# Altium schematic sheet Steering Wheel Interface.SchDoc("Steering Wheel Interface")
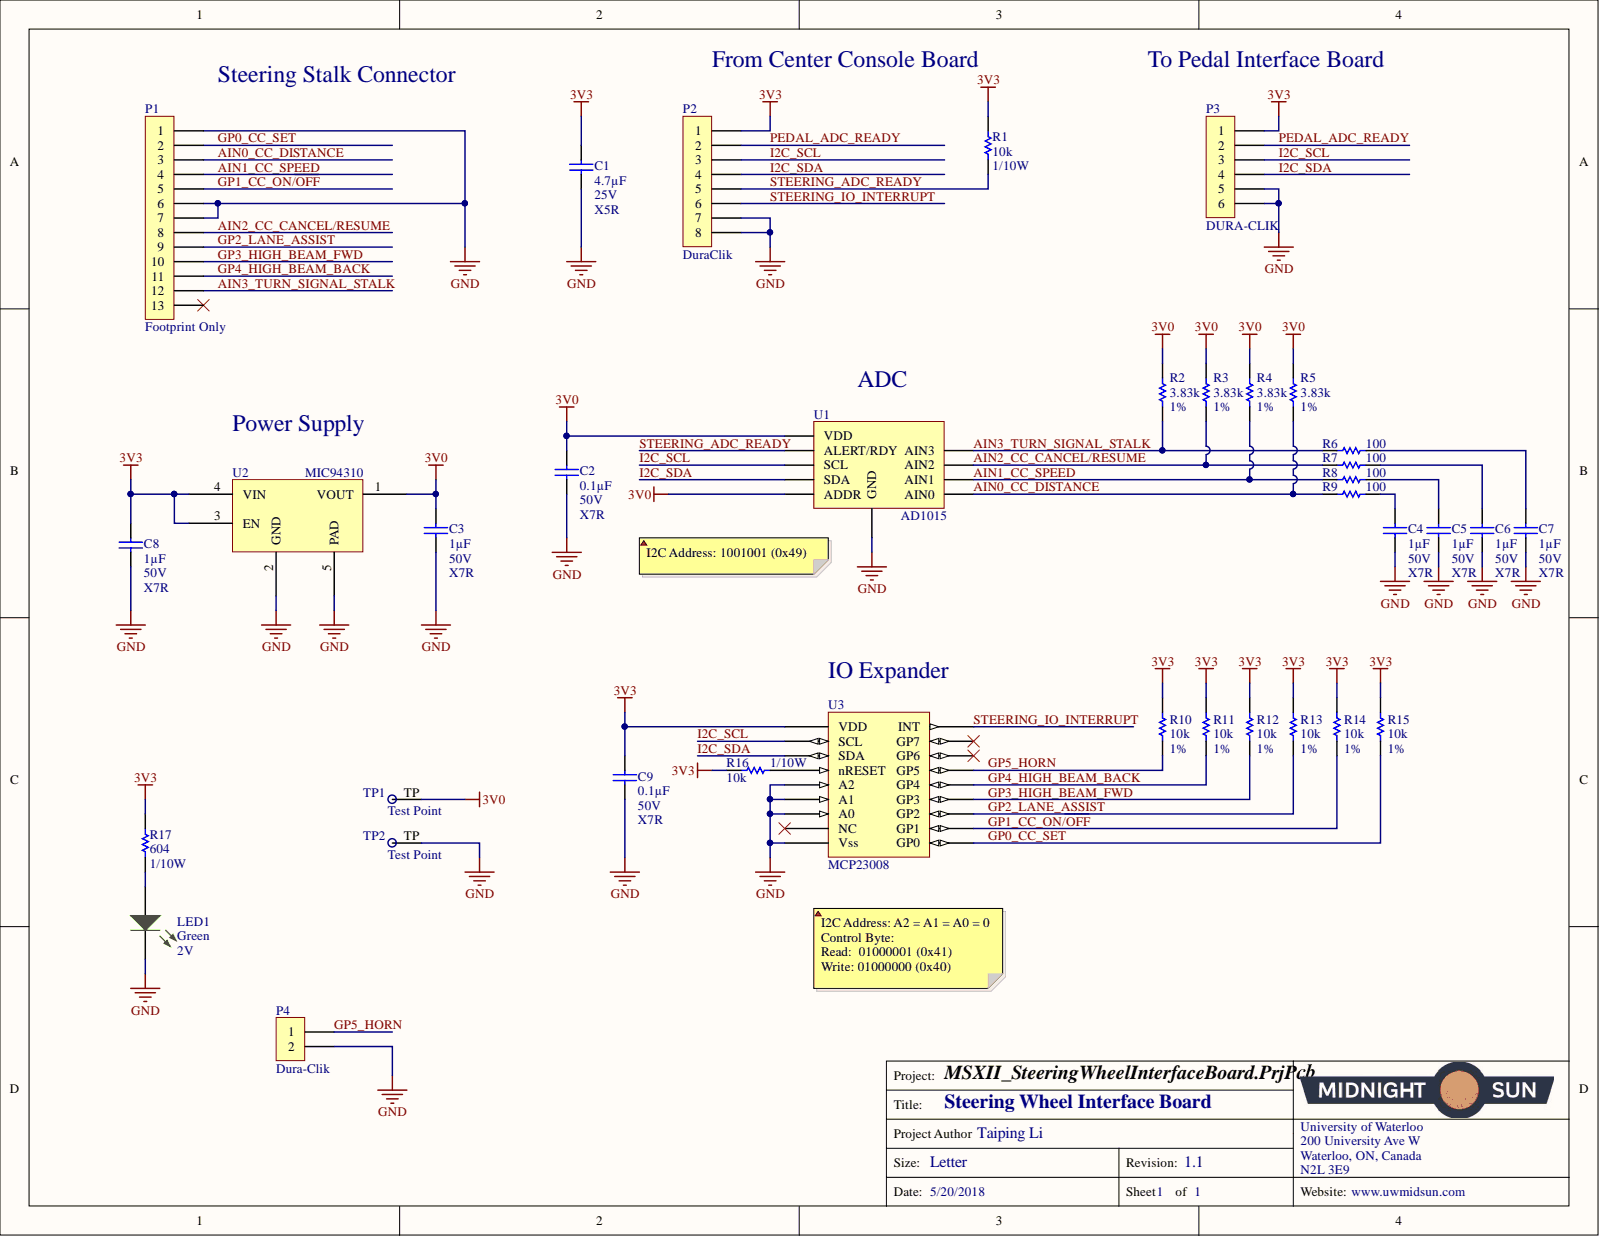
[diagram p0: Steering Wheel Interface.SchDoc]

## Parts
C1  Voltage: 25V  Temperature Coefficient: X5R  Capacitance: 4.7µF  [CAP, 0603]  (hidden comment: CAP CER 4.7UF 25V 10% X5R 0603)
C2  Voltage: 50V  Temperature Coefficient: X7R  Capacitance: 0.1µF  [CAP, 0603]  (hidden comment: CAP CER 0.1UF 50V 10% X7R 0603)
C3  Voltage: 50V  Temperature Coefficient: X7R  Capacitance: 1µF  [CAP, 0603]  (hidden comment: CAP CER 1UF 50V 10% X7R 0603)
C4  Voltage: 50V  Temperature Coefficient: X7R  Capacitance: 1µF  [CAP, 0603]  (hidden comment: CAP CER 1UF 50V 10% X7R 0603)
C5  Voltage: 50V  Temperature Coefficient: X7R  Capacitance: 1µF  [CAP, 0603]  (hidden comment: CAP CER 1UF 50V 10% X7R 0603)
C6  Voltage: 50V  Temperature Coefficient: X7R  Capacitance: 1µF  [CAP, 0603]  (hidden comment: CAP CER 1UF 50V 10% X7R 0603)
C7  Voltage: 50V  Temperature Coefficient: X7R  Capacitance: 1µF  [CAP, 0603]  (hidden comment: CAP CER 1UF 50V 10% X7R 0603)
C8  Voltage: 50V  Temperature Coefficient: X7R  Capacitance: 1µF  [CAP, 0603]  (hidden comment: CAP CER 1UF 50V 10% X7R 0603)
C9  Voltage: 50V  Temperature Coefficient: X7R  Capacitance: 0.1µF  [CAP, 0603]  (hidden comment: CAP CER 0.1UF 50V 10% X7R 0603)
LED1  Voltage - Forward (Vf) (Typ): 2V  Color: Green  [LED_0603]  (hidden comment: LED GREEN CLEAR 2V 0603)
P1  Footprint Only  [CONN 13POS CARD EDGE]  (no BOM)
P2  Series: DuraClik  [CONN, 8POS Dura-Clik]  (hidden comment: CONN 8POS DURA-CLIK 0.079")
P3  DURA-CLIK  [CONN, 6POS DURA-CLIK VERT]
P4  Dura-Clik  [CONN, 2POS Dura-Click Vertical]
R1  Resistance: 10k  Power: 1/10W  [RES, 0603]  (hidden comment: RES 10K OHM 1% 1/10W 0603)
R2  Tolerance: 1%  Resistance: 3.83k  [RES, 0603]  (hidden comment: RES 3.83K OHM 1% 1/10W 0603)
R3  Tolerance: 1%  Resistance: 3.83k  [RES, 0603]  (hidden comment: RES 3.83K OHM 1% 1/10W 0603)
R4  Tolerance: 1%  Resistance: 3.83k  [RES, 0603]  (hidden comment: RES 3.83K OHM 1% 1/10W 0603)
R5  Tolerance: 1%  Resistance: 3.83k  [RES, 0603]  (hidden comment: RES 3.83K OHM 1% 1/10W 0603)
R6  RES 100 OHM 1% 1/10W 0603  [RES, 0603]  (value not drawn)
R7  RES 100 OHM 1% 1/10W 0603  [RES, 0603]  (value not drawn)
R8  RES 100 OHM 1% 1/10W 0603  [RES, 0603]  (value not drawn)
R9  RES 100 OHM 1% 1/10W 0603  [RES, 0603]  (value not drawn)
R10  Tolerance: 1%  Resistance: 10k  [RES, 0603]  (hidden comment: RES 10K OHM 1% 1/10W 0603)
R11  Tolerance: 1%  Resistance: 10k  [RES, 0603]  (hidden comment: RES 10K OHM 1% 1/10W 0603)
R12  Tolerance: 1%  Resistance: 10k  [RES, 0603]  (hidden comment: RES 10K OHM 1% 1/10W 0603)
R13  Tolerance: 1%  Resistance: 10k  [RES, 0603]  (hidden comment: RES 10K OHM 1% 1/10W 0603)
R14  Tolerance: 1%  Resistance: 10k  [RES, 0603]  (hidden comment: RES 10K OHM 1% 1/10W 0603)
R15  Tolerance: 1%  Resistance: 10k  [RES, 0603]  (hidden comment: RES 10K OHM 1% 1/10W 0603)
R16  Resistance: 10k  Power: 1/10W  [RES, 0603]  (hidden comment: RES 10K OHM 1% 1/10W 0603)
R17  Power: 1/10W  [RES, 0603]  (hidden comment: RES 604 OHM 1% 1/10W 0603)
TP1  Test Point  [Test Point]  (no BOM)
TP2  Test Point  [Test Point]  (no BOM)
U1  AD1015  [IC ADC VSSOP10]
U2  MIC94310  [IC REG LDO 3V 0.2A 4-TDFN]
U3  MCP23008  [IC 18SOIC]

## Nets
3V0: C2.1 C3.1 R2.1 R3.1 R4.1 R5.1 TP1.TP U1.1(ADDR) U1.8(VDD) U2.1(VOUT)
3V3: C1.1 C8.1 C9.1 P2.1 P3.1 R1.1 R10.1 R11.1 R12.1 R13.1 R14.1 R15.1 R16.1 R17.1 U2.3(EN) U2.4(VIN) U3.18(VDD)
GND: C1.2 C2.2 C3.2 C4.2 C5.2 C6.2 C7.2 C8.2 C9.2 LED1.2 P1.1 P1.6 P1.7 P2.7 P2.8 P3.5 P3.6 P4.2 TP2.TP U1.3(GND) U2.2(GND) U2.5(PAD) U3.3(A2) U3.4(A1) U3.5(A0) U3.9(Vss)
AIN0_CC_DISTANCE: P1.3 R5.2 R9.1 U1.4(AIN0)
AIN1_CC_SPEED: P1.4 R4.2 R8.1 U1.5(AIN1)
AIN2_CC_CANCEL/RESUME: P1.8 R3.2 R7.1 U1.6(AIN2)
AIN3_TURN_SIGNAL_STALK: P1.12 R2.2 R6.1 U1.7(AIN3)
GP0_CC_SET: P1.2 R15.2 U3.10(GP0)
GP1_CC_ON/~{OFF}: P1.5 R14.2 U3.11(GP1)
GP2_LANE_ASSIST: P1.9 R13.2 U3.12(GP2)
GP3_HIGH_BEAM_FWD: P1.10 R12.2 U3.13(GP3)
GP4_HIGH_BEAM_BACK: P1.11 R11.2 U3.14(GP4)
GP5_HORN: P4.1 R10.2 U3.15(GP5)
I2C_SCL: P2.3 P3.3 U1.10(SCL) U3.1(SCL)
I2C_SDA: P2.4 P3.4 U1.9(SDA) U3.2(SDA)
PEDAL_ADC_READY: P2.2 P3.2
STEERING_ADC_READY: P2.5 R1.2 U1.2(ALERT/RDY)
STEERING_IO_INTERRUPT: P2.6 U3.8(INT)
NetC4_1: C4.1 R9.2
NetC5_1: C5.1 R8.2
NetC6_1: C6.1 R7.2
NetC7_1: C7.1 R6.2
NetLED1_1: LED1.1 R17.2
NetR16_2: R16.2 U3.6(nRESET)

Not connected: P1 13; U3 7(NC) 16(GP6) 17(GP7)

## Library data of the parts
C1 = CAP CER 4.7UF 25V 10% X5R 0603: 4.7µF ±10% 25V Ceramic Capacitor X5R 0603 (1608 Metric); Manufacturer Part Number 1: GRM188R61E475KE11D; Manufacturer 1: Murata Electronics North America; Tolerance: ±10%; Thickness (Max): 0.031" (0.80mm); Supplier Part Number 1: 490-7203-1-ND; Supplier 1: Digi-Key; Size / Dimension: 0.063" L x 0.031" W (1.60mm x 0.80mm); Ratings: -; Part Status: Active; Packaging: Cut Tape (CT); Package / Case: 0603 (1608 Metric); Operating Temperature: -55°C ~ 85°C; Mounting Type: Surface Mount, MLCC; Lead Style: -; Lead Spacing: -; Height - Seated (Max): -; ComponentLink1URL: http://www.murata.com/~/media/webrenewal/support/library/catalog/products/capacitor/mlcc/c02e.ashx; ComponentLink1Description: http://www.murata.com/~/media/webrenewal/support/library/catalog/products/capacitor/mlcc/c02e.ashx; Component Kind: Standard; Library Name: Schematic Diagrams.SchLib
C2 C9 = CAP CER 0.1UF 50V 10% X7R 0603: 0.10µF ±10% 50V Ceramic Capacitor X7R 0603 (1608 Metric); Manufacturer Part Number 1: 06035C104KAT2A; Manufacturer 1: AVX Corporation; Tolerance: ±10%; Thickness (Max): 0.035" (0.90mm); Supplier Part Number 1: 478-5052-1-ND; Supplier 1: Digi-Key; Size / Dimension: 0.063" L x 0.032" W (1.60mm x 0.81mm); Ratings: -; Part Status: Active; Packaging: Cut Tape (CT); Package / Case: 0603 (1608 Metric); Operating Temperature: -55°C ~ 125°C; Mounting Type: Surface Mount, MLCC; Lead Style: -; Lead Spacing: -; Height - Seated (Max): -; ComponentLink1URL: http://datasheets.avx.com/X7RDielectric.pdf; ComponentLink1Description: http://datasheets.avx.com/X7RDielectric.pdf; Component Kind: Standard; Library Name: Schematic Diagrams.SchLib
C3-C8 = CAP CER 1UF 50V 10% X7R 0603: 1µF ±10% 50V Ceramic Capacitor X7R 0603 (1608 Metric); Manufacturer Part Number 1: UMK107AB7105KA-T; Manufacturer 1: Taiyo Yuden; Tolerance: ±10%; Thickness (Max): 0.037" (0.95mm); Supplier Part Number 1: 587-3247-1-ND; Supplier 1: Digi-Key; Size / Dimension: 0.063" L x 0.031" W (1.60mm x 0.80mm); Ratings: -; Part Status: Active; Packaging: Cut Tape (CT); Package / Case: 0603 (1608 Metric); Operating Temperature: -55°C ~ 125°C; Mounting Type: Surface Mount, MLCC; Lead Style: -; Lead Spacing: -; Height - Seated (Max): -; ComponentLink1URL: http://www.yuden.co.jp/productdata/catalog/en/mlcc_all_e.pdf; ComponentLink1Description: http://www.yuden.co.jp/productdata/catalog/en/mlcc_all_e.pdf; Component Kind: Standard; Library Name: Schematic Diagrams.SchLib
LED1 = LED GREEN CLEAR 2V 0603: Green 572nm LED Indication - Discrete 2V 0603 (1608 Metric); Manufacturer Part Number: 150060VS75000; Manufacturer: Wurth Electronics Inc.; Wavelength - Dominant: 570nm; Viewing Angle: 140°; Millicandela Rating: 40mcd; Lens Style/Size: Rectangle with Flat Top, 1.10mm x 0.70mm; Lens Color: Colorless; Current - Test: 20mA; Wavelength - Peak: 572nm; Supplier Part Number 1: 732-4980-1-ND; Supplier Device Package: 0603; Supplier 1: Digi-Key; Stock 1: 9408; Size / Dimension: 1.60mm L x 0.80mm W; RoHS: RoHS Compliant; Pricing 1: 1=0.21, 100=0.1617, 500=0.13964 (CAD); Part Status: Active; Packaging: Cut Tape (CT); Package / Case: 0603 (1608 Metric); Mounting Type: Surface Mount; Lens Transparency: Clear; Height (Max): 0.80mm; Features: -; ComponentLink1URL: http://katalog.we-online.de/led/datasheet/150060VS75000.pdf; ComponentLink1Description: http://katalog.we-online.de/led/datasheet/150060VS75000.pdf; Component Kind: Standard; Category: Optoelectronics; Library Name: Schematic Diagrams.SchLib
P1 = CONN 13POS 0.1" CARD EDGE: Library Name: Schematic Diagrams.SchLib
P2 = CONN 8POS DURA-CLIK 0.079": 8 Positions Header Connector 0.079" (2.00mm) Surface Mount Tin; Manufacturer Part Number 1: 5600200820; Manufacturer 1: Molex, LLC; Style: Board to Cable/Wire; Shrouding: Shrouded - 4 Wall; Number of Positions Loaded: All; Number of Positions: 8; Mated Stacking Heights: 9.3mm; Voltage: -; Termination: Solder; Supplier Part Number 1: WM10868CT-ND; Supplier 1: Digi-Key; Row Spacing - Mating: -; Pitch - Mating: 0.079" (2.00mm); Part Status: Active; Packaging: Cut Tape (CT); Overall Contact Length: -; Operating Temperature: -; Number of Rows: 1; Mounting Type: Surface Mount; Material Flammability Rating: -; Insulation Material: Polyamide (PA), Nylon; Insulation Height: 0.315" (8.00mm); Insulation Color: Natural; Ingress Protection: -; Fastening Type: Latch Lock; Current Rating: -; Contact Type: Male Pin; Contact Shape: Square; Contact Material: Copper Alloy; Contact Length - Post: -; Contact Length - Mating: -; Contact Finish Thickness - Post: -; Contact Finish Thickness - Mating: -; Contact Finish - Post: Tin; Contact Finish - Mating: Tin; Connector Type: Header; ComponentLink1URL: http://www.molex.com/pdm_docs/sd/5600200820_sd.pdf; ComponentLink1Description: http://www.molex.com/pdm_docs/sd/5600200820_sd.pdf; Component Kind: Standard; Library Name: Schematic Diagrams.SchLib
P3 = CONN 6POS DURA-CLIK 0.079": 6 Positions Header, Shrouded Connector 0.079" (2.00mm) Surface Mount, Vertical Tin; Manufacturer Part Number: 5600200820; Manufacturer: Molex; Style: Board to Cable/Wire; Shrouding: Shrouded - 4 Wall; Number of Positions Loaded: All; Number of Positions: 6; Mated Stacking Heights: 9.3mm; Voltage Rating: -; Termination: Solder; Supplier Part Number 1: WM10866CT-ND; Supplier 1: Digi-Key; Stock 1: 3826; Row Spacing - Mating: -; RoHS: RoHS Compliant; Pricing 1: 1=1.76, 10=1.542, 25=1.4512, 50=1.391, 100=1.3305, 250=1.20956 (CAD); Pitch - Mating: 0.079" (2.00mm); Part Status: Active; Packaging: Cut Tape (CT); Overall Contact Length: -; Operating Temperature: -; Number of Rows: 1; Mounting Type: Surface Mount; Material Flammability Rating: -; Insulation Material: Polyamide (PA), Nylon; Insulation Height: 0.315" (8.00mm); Insulation Color: Natural; Ingress Protection: -; Features: Solder Retention; Fastening Type: Latch Lock; Current Rating: -; Contact Type: Male Pin; Contact Shape: Square; Contact Material: Copper Alloy; Contact Length - Post: -; Contact Length - Mating: -; Contact Finish Thickness - Post: -; Contact Finish Thickness - Mating: -; Contact Finish - Post: Tin; Contact Finish - Mating: Tin; Connector Type: Header; ComponentLink1URL: http://www.molex.com/pdm_docs/sd/5600200220_sd.pdf; ComponentLink1Description: http://www.molex.com/pdm_docs/sd/5600200220_sd.pdf; Component Kind: Standard; Category: Connectors, Interconnects; Applications: Automotive, Industrial; Library Name: Schematic Diagrams.SchLib
P4 = CONN 2POS DURA-CLIK 0.079" VERT: 2 Positions Header, Shrouded Connector 0.079" (2.00mm) Surface Mount, Vertical Tin; Manufacturer Part Number: 5600200220; Manufacturer: Molex, LLC; Style: Board to Cable/Wire; Shrouding: Shrouded - 4 Wall; Number of Positions Loaded: All; Number of Positions: 2; Mated Stacking Heights: 9.3mm; Voltage Rating: -; Termination: Solder; Supplier Part Number 1: WM10862CT-ND; Supplier 1: Digi-Key; Stock 1: 11912; Row Spacing - Mating: -; RoHS: RoHS Compliant; Pricing 1: 1=1.16, 10=1.012, 25=0.952, 50=0.9122, 100=0.8727, 250=0.79336 (CAD); Pitch - Mating: 0.079" (2.00mm); Part Status: Active; Packaging: Cut Tape (CT); Overall Contact Length: -; Operating Temperature: -; Number of Rows: 1; Mounting Type: Surface Mount; Material Flammability Rating: -; Insulation Material: Polyamide (PA), Nylon; Insulation Height: 0.315" (8.00mm); Insulation Color: Natural; Ingress Protection: -; Features: Solder Retention; Fastening Type: Latch Lock; Current Rating: -; Contact Type: Male Pin; Contact Shape: Square; Contact Material: Copper Alloy; Contact Length - Post: -; Contact Length - Mating: -; Contact Finish Thickness - Post: -; Contact Finish Thickness - Mating: -; Contact Finish - Post: Tin; Contact Finish - Mating: Tin; Connector Type: Header; ComponentLink1URL: http://www.molex.com/pdm_docs/sd/5600200220_sd.pdf; ComponentLink1Description: http://www.molex.com/pdm_docs/sd/5600200220_sd.pdf; Component Kind: Standard; Category: Connectors, Interconnects; Applications: Automotive, Industrial; Library Name: Schematic Diagrams.SchLib
R1 R10-R16 = RES 10K OHM 1% 1/10W 0603: RES SMD 10K OHM 1% 1/10W 0603; Manufacturer Part Number 1: RC0603FR-0710KL; Manufacturer 1: Yageo; Temperature Coefficient: ±100ppm/°C; Supplier Part Number 1: 311-10.0KHRCT-ND; Supplier Device Package: 0603; Supplier 1: Digi-Key; Size / Dimension: 0.063" L x 0.031" W (1.60mm x 0.80mm); Part Status: Active; Packaging: Cut Tape (CT); Package / Case: 0603 (1608 Metric); Operating Temperature: -55°C ~ 155°C; Height - Seated (Max): 0.022" (0.55mm); Failure Rate: -; Composition: Thick Film; ComponentLink1URL: http://www.yageo.com/documents/recent/PYu-RC_Group_51_RoHS_L_7.pdf; ComponentLink1Description: http://www.yageo.com/documents/recent/PYu-RC_Group_51_RoHS_L_7.pdf; Component Kind: Standard; Library Name: Schematic Diagrams.SchLib
  R1 R16: Tolerance: ±1%
  R10-R15: Power: 1/10W
R2-R5 = RES 3.83K OHM 1% 1/10W 0603: 3.83 kOhms ±1% 0.1W, 1/10W Chip Resistor 0603 (1608 Metric) Moisture Resistant Thick Film; Manufacturer Part Number: RC0603FR-073K83L; Manufacturer: Yageo; Working Voltage: 75V; Voltage Rating (DC): 50V; Temperature Coefficient: 100ppm/°C; Width: 0.8mm; Weight: 7.1E-05oz; Voltage Rating: 75V; Termination: SMD/SMT; Supplier Part Number 1: 311-3.83KHRCT-ND; Supplier 1: Digi-Key; Stock 1: 150132; Pricing 1: 1=0.1, 10=0.015, 100=0.006, 1000=0.00268, 2500=0.00233 (USD); Power Rating: 1/10 W; Packaging: Tape and Reel; Number of Terminations: 2; Mount: Surface Mount; Min Operating Temperature: -55°C; Military Standard: Not; Max Operating Temperature: 155°C; Length: 1.6mm; Lead Free: Lead Free; Height: 0.45mm; Depth: 0.8mm; Composition: Thick Film; ComponentLink2URL: https://datasheet.ciiva.com/15189/getdatasheetpartid-327814-15189723.pdf; ComponentLink2Description: https://datasheet.ciiva.com/15189/getdatasheetpartid-327814-15189723.pdf; ComponentLink1URL: https://datasheet.ciiva.com/11819/0900766b80f96399-11819347.pdf; ComponentLink1Description: https://datasheet.ciiva.com/11819/0900766b80f96399-11819347.pdf; Component Kind: Standard; Case Code (Metric): 1608; Case Code (Imperial): 0603; Case/Package: 0603; Library Name: Schematic Diagrams.SchLib
R6-R9 = RES 100 OHM 1% 1/10W 0603: 100 Ohm ±1% 0.1W, 1/10W Chip Resistor 0603 (1608 Metric) Moisture Resistant Thick Film; Manufacturer Part Number 1: RC0603FR-07100RL; Manufacturer 1: Yageo; Temperature Coefficient: ±100ppm/°C; Resistance: 100; Tolerance: ±1%; Supplier Part Number 1: 311-100HRCT-ND; Supplier Device Package: 0603; Supplier 1: Digi-Key; Size / Dimension: 0.063" L x 0.031" W (1.60mm x 0.80mm); Part Status: Active; Packaging: Cut Tape (CT); Package / Case: 0603 (1608 Metric); Operating Temperature: -55°C ~ 155°C; Height - Seated (Max): 0.022" (0.55mm); Failure Rate: -; Composition: Thick Film; ComponentLink1URL: http://www.yageo.com/documents/recent/PYu-RC_Group_51_RoHS_L_7.pdf; ComponentLink1Description: http://www.yageo.com/documents/recent/PYu-RC_Group_51_RoHS_L_7.pdf; Component Kind: Standard; Library Name: Schematic Diagrams.SchLib
R17 = RES 604 OHM 1% 1/10W 0603: RES SMD 604 OHM 1% 1/10W 0603; Manufacturer Part Number 1: RC0603FR-07604RL; Manufacturer 1: Yageo; Temperature Coefficient: ±100ppm/°C; Resistance: 604; Tolerance: ±1%; Supplier Part Number 1: 311-604HRCT-ND; Supplier Device Package: 0603; Supplier 1: Digi-Key; Size / Dimension: 0.063" L x 0.031" W (1.60mm x 0.80mm); Part Status: Active; Packaging: Cut Tape (CT); Package / Case: 0603 (1608 Metric); Operating Temperature: -55°C ~ 155°C; Height - Seated (Max): 0.022" (0.55mm); Failure Rate: -; Composition: Thick Film; ComponentLink1URL: http://www.yageo.com/documents/recent/PYu-RC_Group_51_RoHS_L_7.pdf; ComponentLink1Description: http://www.yageo.com/documents/recent/PYu-RC_Group_51_RoHS_L_7.pdf; Component Kind: Standard; Library Name: Schematic Diagrams.SchLib
U1 = IC ADC 12-BIT VSSOP-10: 12 Bit Analog to Digital Converter 4 Input 1 Sigma-Delta 10-VSSOP; Manufacturer Part Number: ADS1015IDGSR; Manufacturer: Texas Instruments; Voltage - Supply, Digital: 2 V ~ 5.5 V; Sampling Rate (Per Second): 3.3k; Reference Type: Internal; Number of Inputs: 2, 4; Number of Bits: 12; Number of A/D Converters: 1; Input Type: Differential, Single Ended; Data Interface: I²C; Configuration: MUX-PGA-ADC; Voltage - Supply, Analog: 2 V ~ 5.5 V; Supplier Part Number 1: 296-41185-1-ND; Supplier Device Package: 10-VSSOP; Supplier 1: Digi-Key; Stock 1: 4084; RoHS: RoHS Compliant; Ratio - S/H: -; Pricing 1: 1=4.25, 10=3.807, 100=3.1194, 500=2.65552, 1000=2.23959 (CAD); Part Status: Active; Packaging: Cut Tape (CT); Package / Case: 10-TFSOP, 10-MSOP (0.118", 3.00mm Width); Operating Temperature: -40°C ~ 125°C; Features: PGA, Selectable Address; ComponentLink1URL: http://www.ti.com/lit/ds/symlink/ads1013.pdf; ComponentLink1Description: http://www.ti.com/lit/ds/symlink/ads1013.pdf; Component Kind: Standard; Category: Integrated Circuits (ICs); Architecture: Sigma-Delta; Library Name: Schematic Diagrams.SchLib
U2 = IC REG LDO 3V 0.2A 4-TDFN: Manufacturer Part Number: MIC94310-PYMT-TR; Manufacturer: Microchip Technology; Voltage - Output: 3V; Voltage - Input: Up to 3.6V; Voltage - Dropout (Typical): 0.04V @ 200mA; Regulator Topology: Positive Fixed; Current - Output: 200mA; Current - Limit (Min): 250mA; Supplier Part Number 1: 576-4761-1-ND; Supplier Device Package: 4-TDFN (1.2x1.6); Supplier 1: Digi-Key; Stock 1: 4802; RoHS: RoHS Compliant; Pricing 1: 1=0.45, 25=0.3708, 100=0.3386 (CAD); Part Status: Active; Packaging: Cut Tape (CT); Package / Case: 4-UFDFN Exposed Pad; Operating Temperature: -40°C ~ 125°C; Mounting Type: Surface Mount; ComponentLink1URL: http://www.microchip.com/mymicrochip/filehandler.aspx?ddocname=en580409; ComponentLink1Description: http://www.microchip.com/mymicrochip/filehandler.aspx?ddocname=en580409; Component Kind: Standard; Category: Integrated Circuits (ICs); Library Name: Schematic Diagrams.SchLib
U3 = IC I/O EXPANDER I2C 8B 18SOIC: MCP23008 I/O Expander 8B+1Int 18P SOIC; Manufacturer Part Number: MCP23008T-E/SO; Manufacturer: Microchip Technology; Voltage - Supply: 1.8 V ~ 5.5 V; Output Type: Push-Pull; Number of I/O: 8; Interrupt Output: Yes; Interface: I²C; Current - Output Source/Sink: 25mA; Supplier Part Number 1: MCP23008T-E/SOCT-ND; Supplier Device Package: 18-SOIC; Supplier 1: Digi-Key; Stock 1: 5607; RoHS: RoHS Compliant; Pricing 1: 1=1.62, 25=1.3548, 100=1.2431 (CAD); Part Status: Active; Packaging: Cut Tape (CT); Package / Case: 18-SOIC (0.295", 7.50mm Width); Operating Temperature: -40°C ~ 125°C; Mounting Type: Surface Mount; Features: POR; ComponentLink1URL: http://www.microchip.com/mymicrochip/filehandler.aspx?ddocname=en021920; ComponentLink1Description: http://www.microchip.com/mymicrochip/filehandler.aspx?ddocname=en021920; Component Kind: Standard; Category: Integrated Circuits (ICs); Library Name: Schematic Diagrams.SchLib
TP1 TP2 = Test Point: Library Name: Schematic Diagrams.SchLib
